# Altium schematic sheet wsnfinalV2.SchDoc
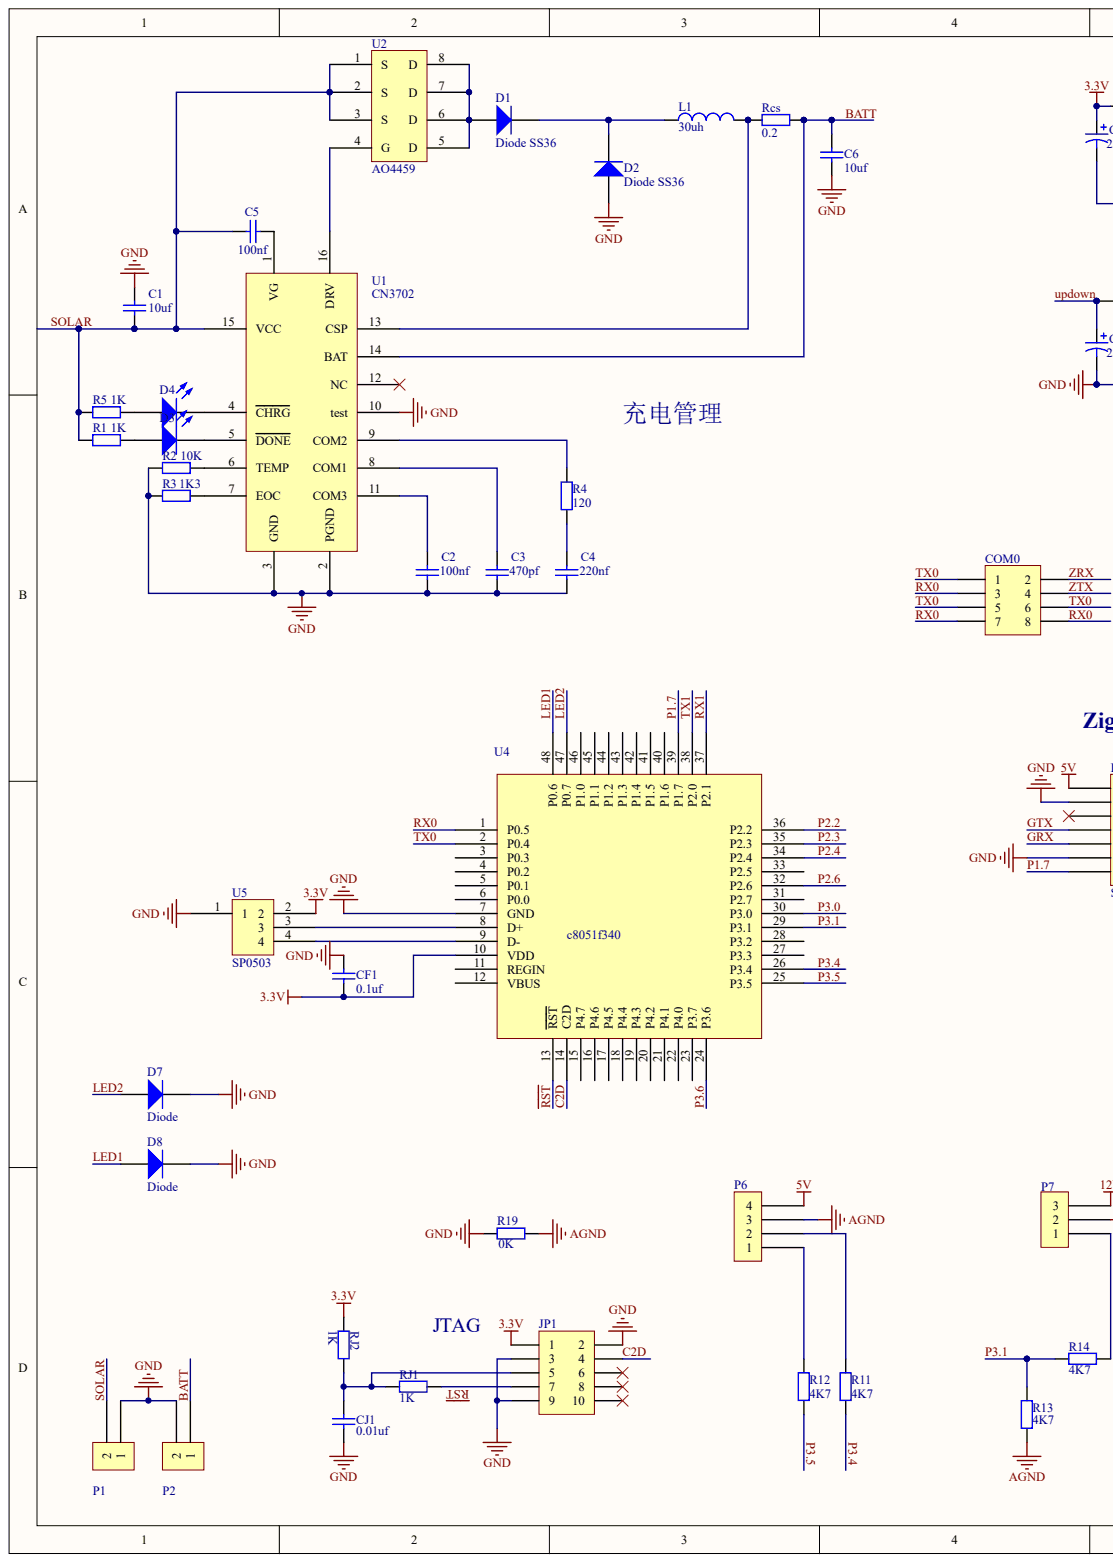
[diagram p0: wsnfinalV2.SchDoc, left]
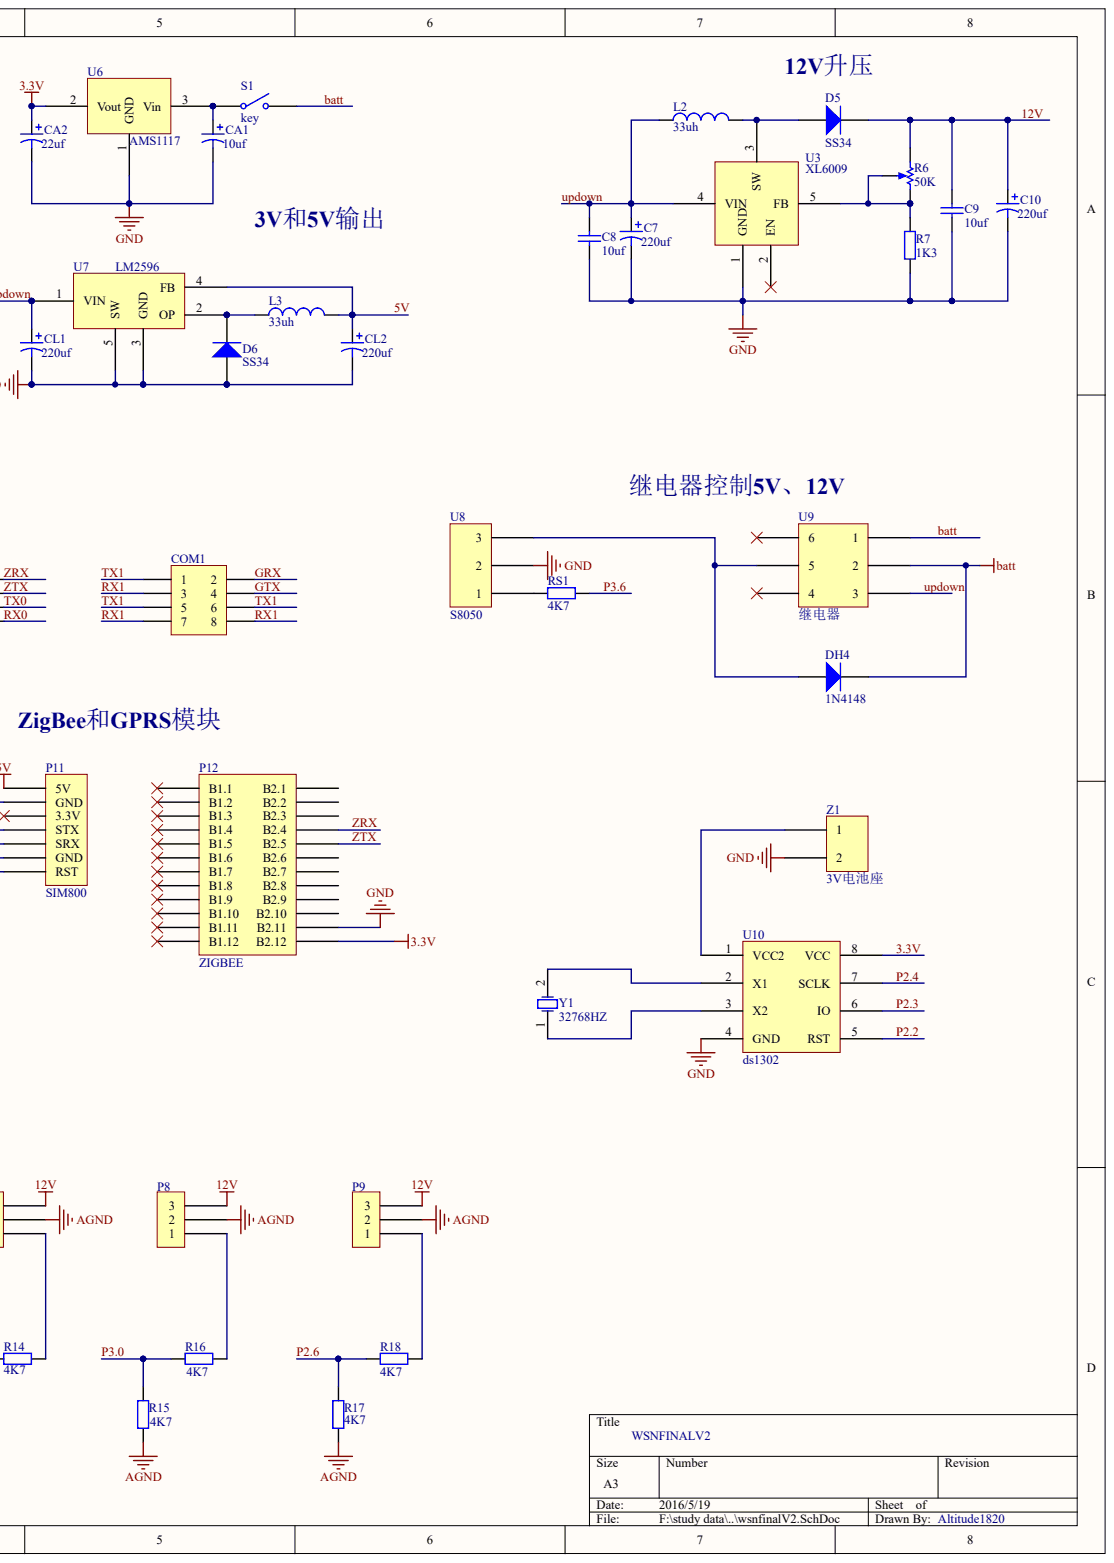
[diagram p1: wsnfinalV2.SchDoc, right]

## Parts
C1  value 10uf  (hidden comment: Cap)
C2  value 100nf  (hidden comment: Cap)
C3  value 470pf  (hidden comment: Cap)
C4  value 220nf  (hidden comment: Cap)
C5  value 100nf  (hidden comment: Cap)
C6  value 10uf  (hidden comment: Cap)
C7  value 220uf  (hidden comment: Cap Pol1)
C8  value 10uf  (hidden comment: Cap)
C9  value 10uf  (hidden comment: Cap)
C10  value 220uf  (hidden comment: Cap Pol1)
CA1  value 10uf  (hidden comment: Cap Pol1)
CA2  value 22uf  (hidden comment: Cap Pol1)
CF1  value 0.1uf  (hidden comment: Cap)
CJ1  value 0.01uf  (hidden comment: Cap)
CL1  value 220uf  (hidden comment: Cap Pol1)
CL2  value 220uf  (hidden comment: Cap Pol1)
COM0  Header 4X2  (value not drawn)
COM1  Header 4X2  (value not drawn)
D1  Diode SS36
D2  Diode SS36
D3  LED0  (value not drawn)
D4  LED0  (value not drawn)
D5  SS34
D6  SS34
D7  Diode
D8  Diode
DH4  1N4148
JP1  Header 5X2  (value not drawn)
L1  value 30uh  (hidden comment: Inductor)
L2  value 33uh  (hidden comment: Inductor)
L3  value 33uh  (hidden comment: Inductor)
P1  JIETOU  (value not drawn)
P2  JIETOU  (value not drawn)
P6  温湿度传感器接口  (value not drawn)
P7  3P  (value not drawn)
P8  3P  (value not drawn)
P9  3P  (value not drawn)
P11  SIM800
P12  ZIGBEE
R1  value 1K  (hidden comment: Res2)
R2  value 10K  (hidden comment: Res2)
R3  value 1K3  (hidden comment: Res2)
R4  value 120  (hidden comment: Res2)
R5  value 1K  (hidden comment: Res2)
R6  value 50K  (hidden comment: RPot)
R7  value 1K3  (hidden comment: Res2)
R11  value 4K7  (hidden comment: Res2)
R12  value 4K7  (hidden comment: Res2)
R13  value 4K7  (hidden comment: Res2)
R14  value 4K7  (hidden comment: Res2)
R15  value 4K7  (hidden comment: Res2)
R16  value 4K7  (hidden comment: Res2)
R17  value 4K7  (hidden comment: Res2)
R18  value 4K7  (hidden comment: Res2)
R19  value 0K  (hidden comment: Res2)
Rcs  value 0.2  (hidden comment: Res2)
RJ1  value 1K  (hidden comment: Res2)
RJ2  value 1K  (hidden comment: Res2)
RS1  value 4K7  (hidden comment: Res2)
S1  key
U1  CN3702
U2  AO4459
U3  XL6009
U4  c8051f340
U5  SP0503
U6  AMS1117
U7  LM2596
U8  S8050
U9  继电器
U10  ds1302
Y1  32768HZ
Z1  3V电池座

## Nets
AGND: P6.3 P7.2 P8.2 P9.2 R13.1 R15.1 R17.1 R19.2
GND: C1.2 C2.2 C3.2 C4.2 C6.2 C7.2 C8.2 C9.2 C10.2 CA1.2 CA2.2 CF1.2 CJ1.1 CL1.2 CL2.2 D2.1 D6.1 D7.2 D8.2 JP1.2 JP1.3 JP1.9 P1.1 P2.2 P11.2(GND) P11.6(GND) P12.J2.11(B2.11) R2.1 R3.1 R7.2 R19.1 U1.2(PGND) U1.3(GND) U1.10(test) U3.1(GND) U4.7(GND) U5.1 U6.1 U7.3(GND) U7.5(SW) U8.2 U10.4(GND) Z1.2
3.3V: CA2.1 CF1.1 JP1.1 P12.J2.12(B2.12) RJ2.2 U4.10(VDD) U5.2 U6.2 U10.8(VCC)
5V: CL2.1 L3.2 P6.4 P11.1(5V) U7.4(FB)
12V: C9.1 C10.1 D5.2 P7.3 P8.3 P9.3 R6.1
BATT: C6.1 DH4.2 P2.1 Rcs.2 S1.2 U1.14(BAT) U9.1 U9.2
C2D: JP1.4 U4.14(C2D)
GRX: COM1.2 P11.5(SRX)
GTX: COM1.4 P11.4(STX)
LED1: D8.1 U4.48(P0.6)
LED2: D7.1 U4.47(P0.7)
P1.7: P11.7(RST) U4.39(P1.7)
P2.2: U4.36(P2.2) U10.5(RST)
P2.3: U4.35(P2.3) U10.6(IO)
P2.4: U4.34(P2.4) U10.7(SCLK)
P2.6: R17.2 R18.1 U4.32(P2.6)
P3.0: R15.2 R16.1 U4.30(P3.0)
P3.1: R13.2 R14.1 U4.29(P3.1)
P3.4: R11.1 U4.26(P3.4)
P3.5: R12.2 U4.25(P3.5)
P3.6: RS1.2 U4.24(P3.6)
~{RST}: JP1.7 RJ1.2 U4.13(RST)
RX0: COM0.3 COM0.7 COM0.8 U4.1(P0.5)
RX1: COM1.3 COM1.7 COM1.8 U4.37(P2.1)
SOLAR: C1.1 C5.2 P1.2 R1.1 R5.1 U1.15(VCC) U2.1(S) U2.2(S) U2.3(S)
TX0: COM0.1 COM0.5 COM0.6 U4.2(P0.4)
TX1: COM1.1 COM1.5 COM1.6 U4.38(P2.0)
UPDOWN: C7.1 C8.1 CL1.1 L2.1 U3.4(VIN) U7.1(VIN) U9.3
ZRX: COM0.2 P12.J2.4(B2.4)
ZTX: COM0.4 P12.J2.5(B2.5)
NetC2_1: C2.1 U1.11(COM3)
NetC3_1: C3.1 U1.8(COM1)
NetC4_1: C4.1 R4.2
NetC5_1: C5.1 U1.1(VG)
NetCA1_1: CA1.1 S1.1 U6.3
NetCJ1_2: CJ1.2 JP1.5 RJ1.1 RJ2.1
NetD1_1: D1.1 U2.5(D) U2.6(D) U2.7(D) U2.8(D)
NetD1_2: D1.2 D2.2 L1.1
NetD3_1: D3.1 R1.2
NetD3_2: D3.2 U1.5(DONE)
NetD4_1: D4.1 R5.2
NetD4_2: D4.2 U1.4(CHRG)
NetD5_1: D5.1 L2.2 U3.3(SW)
NetD6_2: D6.2 L3.1 U7.2(OP)
NetDH4_1: DH4.1 U8.3 U9.5
NetL1_2: L1.2 Rcs.1 U1.13(CSP)
NetP6_1: P6.1 R12.1
NetP6_2: P6.2 R11.2
NetP7_1: P7.1 R14.2
NetP8_1: P8.1 R16.2
NetP9_1: P9.1 R18.2
NetR2_2: R2.2 U1.6(TEMP)
NetR3_2: R3.2 U1.7(EOC)
NetR4_1: R4.1 U1.9(COM2)
NetR6_2: R6.2 R6.3 R7.1 U3.5(FB)
NetRS1_1: RS1.1 U8.1
NetU1_16: U1.16(DRV) U2.4(G)
NetU4_8: U4.8(D+) U5.3
NetU4_9: U4.9(D-) U5.4
NetU10_1: U10.1(VCC2) Z1.1
NetU10_2: U10.2(X1) Y1.2
NetU10_3: U10.3(X2) Y1.1

Not connected: JP1 6 8 10; P11 3(3.3V); P12 J1.1(B1.1) J1.2(B1.2) J1.3(B1.3) J1.4(B1.4) J1.5(B1.5) J1.6(B1.6) J1.7(B1.7) J1.8(B1.8) J1.9(B1.9) J1.10(B1.10) J1.11(B1.11) J1.12(B1.12) J2.1(B2.1) J2.2(B2.2) J2.3(B2.3) J2.6(B2.6) J2.7(B2.7) J2.8(B2.8) J2.9(B2.9) J2.10(B2.10); U1 12(NC); U3 2(EN); U4 3(P0.3) 4(P0.2) 5(P0.1) 6(P0.0) 11(REGIN) 12(VBUS) 15(P4.7) 16(P4.6) 17(P4.5) 18(P4.4) 19(P4.3) 20(P4.2) 21(P4.1) 22(P4.0) 23(P3.7) 27(P3.3) 28(P3.2) 31(P2.7) 33(P2.5) 40(P1.6) 41(P1.5) 42(P1.4) 43(P1.3) 44(P1.2) 45(P1.1) 46(P1.0); U9 4 6

## Library data of the parts
L1-L3 = (no symbol name): Code_IEC: 1005; Published: 8-Jun-2000; LatestRevisionDate: 29-May-2009; LatestRevisionNote: IPC-7351 Footprint Added.; PackageReference: 0402-A; PackageDescription: Chip Inductor; Code_JEITA: 0402; Publisher: Altium Limited
R6 = (no symbol name): Sim Note: Enter the Resistance value and the set point into parameter fields.; Set Position: 0.5; Published: 8-Jun-2000; LatestRevisionDate: 17-Jul-2002; LatestRevisionNote: Re-released for DXP Platform.; PackageReference: VR5; PackageDescription: Variable Resistor, Thru-Hole; 3 Leads; Publisher: Altium Limited
C1-C10 CA1 CA2 CF1 CJ1 CL1 CL2 COM0 COM1 D1-D8 DH4 JP1 P1 P2 P6-P9 P11 P12 R1-R5 R7 R11-R19 Rcs RJ1 RJ2 RS1 S1 U1-U10 Y1 Z1 = (no symbol name)
  C1-C6 C8 C9 CF1 CJ1: Published: 8-Jun-2000; LatestRevisionDate: 17-Jul-2002; LatestRevisionNote: Re-released for DXP Platform.; PackageReference: RAD-0.3; PackageDescription: Radial Cap, Thru-Hole; 2 Leads; 0.3 in Pin Spacing
  C7 C10 CA1 CA2 CL1 CL2: Published: 8-Jun-2000; LatestRevisionDate: 17-Jul-2002; LatestRevisionNote: Re-released for DXP Platform.; PackageReference: RB7.6-15; PackageDescription: Polarized Capacitor (Radial); 2 Leads
  COM0 COM1 JP1: LatestRevisionDate: 17-Jul-2002; LatestRevisionNote: Re-released for DXP Platform.
  D1 D2 D5 D6: Published: 8-Jun-2000; LatestRevisionDate: 17-Jul-2002; LatestRevisionNote: Re-released for DXP Platform.; DatasheetVersion: 1998; PackageVersion: Aug-1999; PackageReference: DO-41; PackageDescription: Diode, Axial; Body 5.2 x 2.7 mm (LxDia. max), Lead Dia. 0.86 mm (max); Code_JEDEC: DO-204-AL; ComponentLink1Description: Manufacturer Link; ComponentLink1URL: http://www.fairchildsemi.com/; ComponentLink2Description: Datasheet; ComponentLink2URL: http://www.fairchildsemi.com/ds/1N%2F1N4001.pdf
  D3 D4: Published: 8-Jun-2000; LatestRevisionDate: 08-Jul-2005; LatestRevisionNote: Mech Layer 1 removed.; PackageReference: LED-0; PackageDescription: LED; 2 Leads
  D7 D8: Published: 8-Jun-2000; LatestRevisionDate: 17-Jul-2002; LatestRevisionNote: Re-released for DXP Platform.; PackageVersion: Sep-1996; PackageReference: SMC; PackageDescription: DO-214-AB/SMC; 2 C-Bend Leads; Body 7.9 x 5.9 mm, inc. leads (LxW); Code_JEDEC: DO-214-AB
  DH4: Published: 8-Jun-2000; LatestRevisionDate: 17-Jul-2002; LatestRevisionNote: Re-released for DXP Platform.; DatasheetVersion: 1997; PackageReference: DO-35; PackageDescription: Diode, Axial; Body 3.8 x 1.9 mm (LxDia. max), Lead Dia. 0.53 mm (max); Code_JEDEC: DO-204-AH; ComponentLink1Description: Manufacturer Link; ComponentLink1URL: http://www.fairchildsemi.com/; ComponentLink2Description: Datasheet; ComponentLink2URL: http://www.fairchildsemi.com/ds/1N%2F1N4148.pdf
  R1-R5 R7 R11-R19 Rcs RJ1 RJ2 RS1: Published: 8-Jun-2000; LatestRevisionDate: 17-Jul-2002; LatestRevisionNote: Re-released for DXP Platform.; PackageReference: AXIAL-0.4; PackageDescription: Axial Device, Thru-Hole; 2 Leads; 0.4 in Pin Spacing
  S1: Published: 24-Mar-1999; LatestRevisionDate: 17-Jul-2002; LatestRevisionNote: Re-released for DXP Platform.; PackageReference: SPST-2; PackageDescription: Switch; 2 Leads
  Y1: Published: 8-Jun-2000; LatestRevisionDate: 17-Jul-2002; LatestRevisionNote: Re-released for DXP Platform.; PackageReference: R38; PackageDescription: Crystal, Thru-Hole; 2 Leads; Body 3.1 x 8.2 mm (Dia.xH)
  C1-C10 CA1 CA2 CF1 CJ1 CL1 CL2 COM0 COM1 D1-D8 DH4 JP1 R1-R5 R7 R11-R19 Rcs RJ1 RJ2 RS1 S1 Y1: Publisher: Altium Limited
